# Altium schematic sheet [03] - 28PINS SCHEMATIC.SchDoc("[03] - 28PINS SCHEMATIC") (continued)

## Library data of the parts
C1 C2 = EEE-1AA101WR: CAP ALUM 100UF 10V 20% SMD; Manufacturer: Panasonic Electronic Components; .Checked PCB: RF; .Creator: MM; Capacitance: 100µF; Lifetime @ Temp.: 1000 Hrs @ 85°C; Ripple Current: 50mA; Surface Mount Land Size: 0.209" L x 0.209" W (5.30mm x 5.30mm); Applications: Automotive; Category: Capacitors; Component Kind: Standard; ComponentLink1Description: http://industrial.panasonic.com/www-cgi/jvcr13pz.cgi?E+PZ+3+ABA0002+EEE1AA101WR+7+WW; ComponentLink1URL: http://industrial.panasonic.com/www-cgi/jvcr13pz.cgi?E+PZ+3+ABA0002+EEE1AA101WR+7+WW; ESR (Equivalent Series Resistance): -; Height - Seated (Max): 0.213" (5.40mm); Impedance: -; Lead Spacing: -; Manufacturer Part Number: EEE-1AA101WR; Mounting Type: Surface Mount; Operating Temperature: -40°C ~ 85°C; Package / Case: Radial, Can - SMD; Packaging: Cut Tape (CT); Pricing 1: 1=0.44, 10=0.345, 25=0.2904, 50=0.249, 100=0.2076, 250=0.15568, 500=0.13284 (USD); RoHS: RoHS Compliant; Size / Dimension: 0.197" Dia (5.00mm); Stock 1: 50742; Supplier 1: Digi-Key; Supplier Part Number 1: PCE3867CT-ND; Tolerance: ±20%; Type: -; .Checked SCH: RF; Library Name: Integrated_Library_FEDEVEL_APR_2015.IntLib
  C1: Voltage Rating: 10V
C3 C12 C13 C19 C21 C22 C34 = C2012X5R1C106M: CAP CER 10UF 16V 20% X5R 0805; Manufacturer: TDK Corporation; Manufacturer Part Number: C2012X5R1C106M/1.25; .Checked PCB: used; Capacitance: 10µF; .Checked SCH: used; Applications: General Purpose; Category: Capacitors; Component Kind: Standard; ComponentLink1Description: http://www.tdk.com/pdf/general_B11.pdf; ComponentLink1URL: http://www.tdk.com/pdf/general_B11.pdf; Features: -; Height - Seated (Max): -; Lead Spacing: -; Lead Style: -; Mounting Type: Surface Mount, MLCC; Operating Temperature: -55°C ~ 85°C; Package / Case: 0805 (2012 Metric); Packaging: Cut Tape (CT); Pricing 1: 1=0.45, 10=0.357, 100=0.215, 250=0.16124, 500=0.1376, 1000=0.1075 (USD); Ratings: -; RoHS: RoHS Compliant; Size / Dimension: 0.079" L x 0.049" W (2.00mm x 1.25mm); Stock 1: 66161; Supplier 1: Digi-Key; Supplier Part Number 1: 445-4115-1-ND; Temperature Coefficient: X5R; Thickness (Max): 0.039" (1.00mm); Tolerance: ±20%; Library Name: Integrated_Library_FEDEVEL_APR_2015.IntLib
  C3 C22: Voltage - Rated: 16V
C4-C6 C8 C11 C14-C17 C20 C23 C24 C26 C28-C33 = C2012X7R1H104K085AA: CAP CER 0.1UF 50V 10% X7R 0805; Manufacturer: TDK Corporation; .Checked PCB: RF; .Creator: MM; Capacitance: 0.1µF; Applications: General Purpose; Category: Capacitors; Component Kind: Standard; ComponentLink1Description: http://product.tdk.com/en/catalog/datasheets/mlcc_commercial_general_en.pdf; ComponentLink1URL: http://product.tdk.com/en/catalog/datasheets/mlcc_commercial_general_en.pdf; Features: -; Height - Seated (Max): -; Lead Spacing: -; Lead Style: -; Manufacturer Part Number: C2012X7R1H104K085AA; Mounting Type: Surface Mount, MLCC; Operating Temperature: -55°C ~ 125°C; Package / Case: 0805 (2012 Metric); Packaging: Cut Tape (CT); Pricing 1: 1=0.1, 10=0.066, 100=0.0303, 250=0.02476, 500=0.02118, 1000=0.0165 (USD); Ratings: -; RoHS: RoHS Compliant; Size / Dimension: 0.079" L x 0.049" W (2.00mm x 1.25mm); Stock 1: 818066; Supplier 1: Digi-Key; Supplier Part Number 1: 445-7534-1-ND; Temperature Coefficient: X7R; Thickness (Max): 0.037" (0.95mm); Tolerance: ±10%; Voltage - Rated: 50V; .Checked SCH: RF; Library Name: Integrated_Library_FEDEVEL_APR_2015.IntLib
C7 = C2012X5R1E105K125AA: CAP CER 1UF 25V 10% X5R 0805; Manufacturer: TDK Corporation; .Checked PCB: RF; .Creator: MM; Capacitance: 1µF; Applications: General Purpose; Category: Capacitors; Component Kind: Standard; ComponentLink1Description: http://product.tdk.com/en/catalog/datasheets/mlcc_commercial_general_en.pdf; ComponentLink1URL: http://product.tdk.com/en/catalog/datasheets/mlcc_commercial_general_en.pdf; Features: -; Height - Seated (Max): -; Lead Spacing: -; Lead Style: -; Manufacturer Part Number: C2012X5R1E105K125AA; Mounting Type: Surface Mount, MLCC; Operating Temperature: -55°C ~ 85°C; Package / Case: 0805 (2012 Metric); Packaging: Cut Tape (CT); Pricing 1: 1=0.16, 10=0.112, 100=0.0533, 250=0.04488, 500=0.03758, 1000=0.03025 (USD); Ratings: -; RoHS: RoHS Compliant; Size / Dimension: 0.079" L x 0.049" W (2.00mm x 1.25mm); Stock 1: 57052; Supplier 1: Digi-Key; Supplier Part Number 1: 445-1419-1-ND; Temperature Coefficient: X5R; Thickness (Max): 0.057" (1.45mm); Tolerance: ±10%; Voltage - Rated: 25V; .Checked SCH: RF; Library Name: Integrated_Library_FEDEVEL_APR_2015.IntLib
C9 C10 C25 C27 = C0805C180J5GACTU: CAP CER 18PF 50V 5% NP0 0805; Manufacturer: Kemet; .Checked PCB: RF; .Creator: MM; Temperature Coefficient: C0G, NP0; Applications: General Purpose; Capacitance: 18pF; Category: Capacitors; Component Kind: Standard; ComponentLink1Description: http://www.kemet.com/docfinder?Partnumber=C0805C180J5GACTU; ComponentLink1URL: http://www.kemet.com/docfinder?Partnumber=C0805C180J5GACTU; Features: -; Height - Seated (Max): -; Lead Spacing: -; Lead Style: -; Manufacturer Part Number: C0805C180J5GACTU; Mounting Type: Surface Mount, MLCC; Operating Temperature: -55°C ~ 125°C; Package / Case: 0805 (2012 Metric); Packaging: Cut Tape (CT); Pricing 1: 1=0.11, 10=0.083, 25=0.0588, 50=0.045, 100=0.0381, 250=0.0312, 1000=0.0204 (USD); Ratings: -; RoHS: RoHS Compliant; Size / Dimension: 0.079" L x 0.049" W (2.00mm x 1.25mm); Stock 1: 39241; Supplier 1: Digi-Key; Supplier Part Number 1: 399-1112-1-ND; Thickness (Max): 0.035" (0.88mm); Tolerance: ±5%; Voltage - Rated: 50V; .Checked SCH: RF; Library Name: Integrated_Library_FEDEVEL_APR_2015.IntLib
D1 = LG L29K-G2J1-24-Z: LED SMARTLED GREEN 570NM 0603; Manufacturer: OSRAM Opto Semiconductors Inc; .Checked PCB: used; Current - Limit (Min): 600mA; Current - Output: 500mA; Current - Test: 2mA; Lens Style/Size: Rectangle with Flat Top, 1.3mm x 0.8mm; Lens Type: Diffused; Luminous Flux @ Current - Test: 12 mlm; Millicandela Rating: 3.92mcd; Number of Regulators: 1; Regulator Topology: Positive Fixed; Viewing Angle: 160°; Voltage - Dropout (Typical): 0.16V @ 500mA; Voltage - Forward (Vf) Typ: 1.7V; Voltage - Input: 2.6 V ~ 6 V; Voltage - Output: 2.5V; Wavelength - Peak: 572nm; .Checked SCH: used; Category: Optoelectronics; Color: Green; Component Kind: Standard; ComponentLink1Description: http://catalog.osram-os.com/catalogue/catalogue.do;jsessionid=32CB61882C089EDD4B6D0A54E7E1F117?act=downloadFile&favOid=020000020000138e000100b6; ComponentLink1URL: http://catalog.osram-os.com/catalogue/catalogue.do;jsessionid=32CB61882C089EDD4B6D0A54E7E1F117?act=downloadFile&favOid=020000020000138e000100b6; ComponentLink2Description: http://www.micrel.com/_PDF/mic5353.pdf; ComponentLink2URL: http://www.micrel.com/_PDF/mic5353.pdf; Family: LEDs - <75mA, Discre; Height: 0.65mm; Manufacturer Part Number: LG L29K-G2J1-24-Z; Mounting Type: Surface Mount; Operating Temperature: -40°C ~ 125°C; Package / Case: 0603 (1608 Metric); Packaging: Cut Tape (CT); Price 1k [$]: 0.08744; Pricing 1: 1=0.16, 10=0.137, 100=0.1093, 250=0.09564, 500=0.08744 (USD); RoHS: RoHS Compliant; Size / Dimension: 1.70mm L x 0.80mm W; Stock 1: 30318; Supplier: Digikey; Supplier 1: Digi-Key; Supplier Device Package: 6-TMLF® (1.6x1.6); Supplier Part Number: 475-2709-1-ND; Supplier Part Number 1: 475-2709-1-ND; Value: Green; Wavelength - Dominant: 570nm; Library Name: Integrated_Library_FEDEVEL_APR_2015.IntLib
D2-D4 = LO L29K-H2K1-24-Z: LED SMARTLED ORANGE 606NM 0603; Manufacturer: OSRAM Opto Semiconductors Inc; .Checked PCB: used; Current - Test: 2mA; Lens Style/Size: Rectangle with Flat Top, 1.3mm x 0.8mm; Lens Type: Diffused; Luminous Flux @ Current - Test: 25 mlm; Millicandela Rating: 6.3mcd; Viewing Angle: 160°; Voltage - Forward (Vf) Typ: 1.8V; Wavelength - Peak: 610nm; .Checked SCH: used; Category: Optoelectronics; Color: Orange; Component Kind: Standard; ComponentLink1Description: http://catalog.osram-os.com/catalogue/catalogue.do;jsessionid=302CF83AF637AE24E40AEB0ADA267EDD?act=downloadFile&favOid=020000010003f9de000100b6; ComponentLink1URL: http://catalog.osram-os.com/catalogue/catalogue.do;jsessionid=302CF83AF637AE24E40AEB0ADA267EDD?act=downloadFile&favOid=020000010003f9de000100b6; Height: 0.65mm; Manufacturer Part Number: LO L29K-H2K1-24-Z; Mounting Type: Surface Mount; Package / Case: 0603 (1608 Metric); Packaging: Cut Tape (CT); Pricing 1: 1=0.17, 10=0.141, 100=0.1127, 250=0.0986, 500=0.09016 (USD); RoHS: RoHS Compliant; Size / Dimension: 1.70mm L x 0.80mm W; Stock 1: 15900; Supplier 1: Digi-Key; Supplier Part Number 1: 475-2740-1-ND; Wavelength - Dominant: 606nm; Library Name: Integrated_Library_FEDEVEL_APR_2015.IntLib
D5 D6 = BAT54HT1G: DIODE SCHOTTKY 30V 0.2A SOD323; Manufacturer: Fairchild Semiconductor; .Checked PCB: used; .Creator: MM; Capacitance @ Vr, F: 10pF @ 1V, 1MHz; Current - Average Rectified (Io): 200mA; Current - Reverse Leakage @ Vr: 2µA @ 25V; Reverse Recovery Time (trr): 5ns; Speed: Small Signal =< 200mA (Io), Any Speed; Thermal Resistance: 600°C/W Ja; Voltage - Forward (Vf) (Max) @ If: 800mV @ 100mA; .Checked SCH: used; Category: Discrete Semiconductor Products; Component Kind: Standard; ComponentLink1Description: http://www.fairchildsemi.com/ds/BA/BAT54HT1G.pdf; ComponentLink1URL: http://www.fairchildsemi.com/ds/BA/BAT54HT1G.pdf; Diode Type: Schottky; Manufacturer Part Number: BAT54HT1G; Mounting Type: Surface Mount; Operating Temperature - Junction: -55°C ~ 150°C; Package / Case: SC-76, SOD-323; Packaging: Cut Tape (CT); Pricing 1: 1=0.3, 10=0.276, 25=0.1984, 100=0.1543, 250=0.09696, 500=0.0826, 1000=0.05628 (USD); RoHS: RoHS Compliant; Stock 1: 11388; Supplier 1: Digi-Key; Supplier Device Package: SOD-323; Supplier Part Number 1: BAT54HT1GCT-ND; Voltage - DC Reverse (Vr) (Max): 30V; Library Name: Integrated_Library_FEDEVEL_APR_2015.IntLib
FB1-FB3 = MPZ2012S601AT000: FERRITE CHIP BEAD 600 OHM SMD; Manufacturer: TDK Corporation; .Checked PCB: RF; .Creator: MM; Current Rating: 2A; DC Resistance (DCR): 100 mOhm Max; Filter Type: Differential Mode - Single; Impedance @ Frequency: 600 Ohm @ 100MHz; Category: Filters; Component Kind: Standard; ComponentLink1Description: http://product.tdk.com/en/catalog/datasheets/beads_commercial_power_mpz_en.pdf; ComponentLink1URL: http://product.tdk.com/en/catalog/datasheets/beads_commercial_power_mpz_en.pdf; Height (Max): 0.041" (1.05mm); Manufacturer Part Number: MPZ2012S601AT000; Mounting Type: Surface Mount; Package / Case: 0805 (2012 Metric); Packaging: Cut Tape (CT); Pricing 1: 1=0.12, 10=0.101, 100=0.0574, 250=0.04528, 500=0.03824, 1000=0.03221 (USD); RoHS: RoHS Compliant; Size / Dimension: 0.079" L x 0.049" W (2.00mm x 1.25mm); Stock 1: 200620; Supplier 1: Digi-Key; Supplier Part Number 1: 445-2206-1-ND; .Checked SCH: RF; Library Name: Integrated_Library_FEDEVEL_APR_2015.IntLib
FID1-FID4 = FID_1MMC: Fiducial 40mil Diameter, 120mil Mask; Supplier: Digikey; Library Name: Integrated_Library_FEDEVEL_APR_2015.IntLib
J1 J3 = M20-7820842: 08-WAY SIL VERT SOCKET L/FREE; Manufacturer: Harwin Inc; .Checked PCB: RF; .Creator: MM; Connector Type: Receptacle; Number of Positions: 8; Number of Positions Loaded: All; Pitch: 0.100" (2.54mm); Category: Connectors, Interconnects; Color: Black; Component Kind: Standard; ComponentLink1Description: http://harwin.com/includes/pdfs/M20-782.pdf; ComponentLink1URL: http://harwin.com/includes/pdfs/M20-782.pdf; Contact Finish: Gold; Contact Finish Thickness: Flash; Contact Type: Female Socket; Fastening Type: -; Features: -; Manufacturer Part Number: M20-7820842; Mounting Type: Through Hole; Number of Rows: 1; Packaging: Tube; Pricing 1: 1=1.1, 29=0.91207, 58=0.87397, 116=0.83603, 261=0.76, 522=0.684, 1015=0.608, 2523=0.551 (USD); RoHS: RoHS Compliant; Row Spacing: -; Stock 1: 2070; Supplier 1: Digi-Key; Supplier Part Number 1: 952-1823-ND; Termination: Solder; .Checked SCH: RF; Library Name: Integrated_Library_FEDEVEL_APR_2015.IntLib
J2 = M20-7820646: 06-WAY SIL VERT SOCKET L/FREE; Manufacturer: Harwin Inc; .Checked PCB: MM; Connector Type: Receptacle; Number of Positions: 6; Number of Positions Loaded: All; Pitch: 0.100" (2.54mm); Category: Connectors, Interconnects; Color: Black; Component Kind: Standard; ComponentLink1Description: http://harwin.com/includes/pdfs/M20-782.pdf; ComponentLink1URL: http://harwin.com/includes/pdfs/M20-782.pdf; Contact Finish: Tin; Contact Finish Thickness: -; Contact Type: Female Socket; Fastening Type: -; Features: -; Manufacturer Part Number: M20-7820646; Mounting Type: Through Hole; Number of Rows: 1; Packaging: Tube; Pricing 1: 1=0.96, 38=0.79211, 76=0.75895, 114=0.72596, 266=0.66, 532=0.594, 1026=0.528, 2508=0.4785 (USD); RoHS: RoHS Compliant; Row Spacing: -; Stock 1: 87; Supplier 1: Digi-Key; Supplier Part Number 1: 952-1809-ND; Termination: Solder; .Checked SCH: MM; .Creator: MM; Library Name: Integrated_Library_FEDEVEL_APR_2015.IntLib
J4 = M20-7821046: 10-WAY SIL VERT SOCKET L/FREE; Manufacturer: Harwin Inc; .Checked PCB: RF; .Creator: MM; Connector Type: Receptacle; Number of Positions: 10; Number of Positions Loaded: All; Pitch: 0.100" (2.54mm); Category: Connectors, Interconnects; Color: Black; Component Kind: Standard; ComponentLink1Description: http://harwin.com/includes/pdfs/M20-782.pdf; ComponentLink1URL: http://harwin.com/includes/pdfs/M20-782.pdf; Contact Finish: Tin; Contact Finish Thickness: -; Contact Type: Female Socket; Fastening Type: -; Features: -; Manufacturer Part Number: M20-7821046; Mounting Type: Through Hole; Number of Rows: 1; Packaging: Tube; Pricing 1: 1=1.28, 23=1.12217, 46=1.05609, 69=1.01203, 115=0.968, 253=0.88, 506=0.792, 1012=0.704, 2507=0.638 (USD); RoHS: RoHS Compliant; Row Spacing: -; Stock 1: 214; Supplier 1: Digi-Key; Supplier Part Number 1: 952-1847-ND; Termination: Solder; .Checked SCH: RF; Library Name: Integrated_Library_FEDEVEL_APR_2015.IntLib
J5 J6 = 67997-106HLF: CONN HEADER 6POS .100 STR 30AU; Manufacturer: FCI; .Checked PCB: RF; .Creator: MM; Connector Type: Header, Unshrouded; Number of Positions: 6; Number of Positions Loaded: All; Pitch: 0.100" (2.54mm); Category: Connectors, Interconnects; Color: Black; Component Kind: Standard; ComponentLink1Description: http://portal.fciconnect.com/Comergent//fci/drawing/67996.pdf; ComponentLink1URL: http://portal.fciconnect.com/Comergent//fci/drawing/67996.pdf; Contact Finish: Gold or Gold, GXT™; Contact Finish Thickness: 30µin (0.76µm); Contact Mating Length: 0.230" (5.84mm); Contact Type: Male Pin; Fastening Type: -; Features: -; Manufacturer Part Number: 67997-106HLF; Mounting Type: Through Hole; Number of Rows: 2; Packaging: Bulk; Pricing 1: 1=1.64, 10=1.489, 25=1.3976, 50=1.3368, 100=1.276, 250=1.15444, 500=1.0633, 1000=0.9114, 2500=0.85064 (USD); RoHS: RoHS Compliant; Row Spacing: 0.100" (2.54mm); Stock 1: 1377; Supplier 1: Digi-Key; Supplier Part Number 1: 609-3393-ND; Termination: Solder; .Checked SCH: RF; Library Name: Integrated_Library_FEDEVEL_APR_2015.IntLib
J7 = 10118193-0001LF: CONN USB MICRO B RECPT SMT R/A; Manufacturer: FCI; .Checked PCB: RF; .Checked SCH: MM; Connector Type: USB - micro B; Gender: Receptacle; Number of Contacts: 5; Specifications: USB 2.0; Category: Connectors, Interconnects; Component Kind: Standard; ComponentLink1Description: http://portal.fciconnect.com/Comergent//fci/drawing/10118193.pdf; ComponentLink1URL: http://portal.fciconnect.com/Comergent//fci/drawing/10118193.pdf; Features: Shielded, Solder Retention; Ingress Protection: -; Manufacturer Part Number: 10118193-0001LF; Mounting Type: Surface Mount, Right Angle, Horizontal; Packaging: Cut Tape (CT); Pricing 1: 1=0.46, 10=0.433, 25=0.3712, 50=0.3156, 100=0.3032, 250=0.27224, 500=0.25988 (USD); RoHS: RoHS Compliant; Stock 1: 28642; Supplier 1: Digi-Key; Supplier Part Number 1: 609-4616-1-ND; .Creator: MM; Library Name: Integrated_Library_FEDEVEL_APR_2015.IntLib
J8 J9 = PAD_WITH_HOLE_4MMx1.5MM: Pad with a hole 4mm x 1.5mm; Library Name: Integrated_Library_FEDEVEL_APR_2015.IntLib
JP1 JP4 = 68000-103HLF: BERGSTIK II .100" SR STRAIGHT; Manufacturer: FCI; .Checked PCB: used; .Creator: MM; Connector Type: Header, Unshrouded; Number of Positions: 3; Number of Positions Loaded: All; Pitch: 0.100" (2.54mm); .Checked SCH: used; Category: Connectors, Interconnects; Color: Black; Component Kind: Standard; ComponentLink1Description: http://portal.fciconnect.com/Comergent//fci/drawing/68000.pdf; ComponentLink1URL: http://portal.fciconnect.com/Comergent//fci/drawing/68000.pdf; Contact Finish: Gold or Gold, GXT™; Contact Finish Thickness: 30µin (0.76µm); Contact Mating Length: 0.230" (5.84mm); Contact Type: Male Pin; Fastening Type: -; Features: -; Manufacturer Part Number: 68000-103HLF; Mounting Type: Through Hole; Number of Rows: 1; Packaging: Bulk; Pricing 1: 1=0.15, 10=0.145, 25=0.108, 50=0.0924 (USD); RoHS: RoHS Compliant; Row Spacing: -; Stock 1: 37214; Supplier 1: Digi-Key; Supplier Part Number 1: 609-3461-ND; Termination: Solder; Library Name: Integrated_Library_FEDEVEL_APR_2015.IntLib
JP2 = 68602-204HLF: CONN HEADER 4POS .100 STR 15AU; Manufacturer: FCI; .Checked PCB: RF; .Creator: MM; Connector Type: Header, Unshrouded; Number of Positions: 4; Number of Positions Loaded: All; Pitch: 0.100" (2.54mm); Category: Connectors, Interconnects; Color: Black; Component Kind: Standard; ComponentLink1Description: http://portal.fciconnect.com/Comergent//fci/drawing/67996.pdf; ComponentLink1URL: http://portal.fciconnect.com/Comergent//fci/drawing/67996.pdf; Contact Finish: Gold or Gold, GXT™; Contact Finish Thickness: 15µin (0.38µm); Contact Mating Length: 0.230" (5.84mm); Contact Type: Male Pin; Fastening Type: -; Features: -; Manufacturer Part Number: 68602-204HLF; Mounting Type: Through Hole; Number of Rows: 2; Packaging: Bulk; Pricing 1: 1=0.34, 10=0.326, 25=0.2792, 50=0.2374, 100=0.2281, 250=0.20484, 500=0.19552, 1000=0.16293, 2500=0.14896 (USD); RoHS: RoHS Compliant; Row Spacing: 0.100" (2.54mm); Stock 1: 2795; Supplier 1: Digi-Key; Supplier Part Number 1: 609-3369-ND; Termination: Solder; .Checked SCH: RF; Library Name: Integrated_Library_FEDEVEL_APR_2015.IntLib
JP3 = 68001-204HLF: CONN HEADER 4POS .100 STR 15AU; Manufacturer: FCI; .Checked PCB: RF; Connector Type: Header, Unshrouded; Number of Positions: 4; Number of Positions Loaded: All; Pitch: 0.100" (2.54mm); Category: Connectors, Interconnects; Color: Black; Component Kind: Standard; ComponentLink1Description: http://portal.fciconnect.com/res/en/pdffiles/doc_search/68000.pdf; ComponentLink1URL: http://portal.fciconnect.com/res/en/pdffiles/doc_search/68000.pdf; Contact Finish: Gold; Contact Finish Thickness: 15µin (0.38µm); Contact Mating Length: 0.230" (5.84mm); Contact Type: Male Pin; Fastening Type: -; Features: -; Manufacturer Part Number: 68001-204HLF; Mounting Type: Through Hole; Number of Rows: 1; Packaging: Bulk; Pricing 1: 1=0.7, 10=0.432, 100=0.2485, 250=0.21868, 500=0.1988, 1000=0.17892, 5000=0.12674 (USD); RoHS: RoHS Compliant; Row Spacing: -; Stock 1: 8754; Supplier 1: Digi-Key; Supplier Part Number 1: 609-3402-ND; Termination: Solder; .Checked SCH: RF; Library Name: Integrated_Library_FEDEVEL_APR_2015.IntLib
L1 = L1210R2R2MDWIT: FIXED IND 2.2UH 2A 80 MOHM SMD; Manufacturer: Kemet; .Checked PCB: RF; .Creator: MM; DC Resistance (DCR): 80 mOhm; Frequency - Self Resonant: 190MHz; Frequency - Test: 100kHz; Inductance: 2.2µH; Material - Core: Ferrite; Shielding: Unshielded; Tolerance: ±20%; Type: Wirewound; Category: Inductors, Coils, Chokes; Component Kind: Standard; ComponentLink1Description: http://www.kemet.com//kemet/web/homepage/kechome.nsf/vapubfile/Ferrite%20Products%20Catalog/$file/F3115.pdf; ComponentLink1URL: http://www.kemet.com//kemet/web/homepage/kechome.nsf/vapubfile/Ferrite%20Products%20Catalog/$file/F3115.pdf; Current - Saturation: -; Height - Seated (Max): 0.106" (2.70mm); Manufacturer Part Number: L1210R2R2MDWIT; Mounting Type: Surface Mount; Operating Temperature: -40°C ~ 105°C; Package / Case: 1210 (3225 Metric); Packaging: Cut Tape (CT); Pricing 1: 1=0.52, 10=0.466, 25=0.4372, 50=0.379, 100=0.3499, 250=0.33236, 500=0.30322 (USD); Q @ Freq: -; RoHS: RoHS Compliant; Size / Dimension: 0.126" L x 0.098" W (3.20mm x 2.50mm); Stock 1: 3607; Supplier 1: Digi-Key; Supplier Device Package: 1210; Supplier Part Number 1: 399-9598-1-ND; .Checked SCH: RF; Library Name: Integrated_Library_FEDEVEL_APR_2015.IntLib
LINK1-LINK3 = QPC02SXGN-RC: CONN JUMPER SHORTING .100" GOLD; Manufacturer: Sullins Connector Solutions; .Checked SCH: used; Gender: Female Sockets; Number of Positions or Pins (Grid): 2 (1 x 2); Pitch: 0.100" (2.54mm); Category: Connectors, Interconnects; Color: -; ComponentLink1Description: http://www.sullinscorp.com/drawings/284_SXGN_11510.pdf; ComponentLink1URL: http://www.sullinscorp.com/drawings/284_SXGN_11510.pdf; Contact Finish: Gold; Contact Finish Thickness: Flash; Height: 0.235" (5.97mm); Manufacturer Part Number: QPC02SXGN-RC; Packaging: Bulk; Pricing 1: 1=0.11, 10=0.066, 25=0.0468, 50=0.0384, 100=0.033, 250=0.02792, 500=0.0258, 1000=0.0228, 2500=0.018 (USD); RoHS: RoHS Compliant; Stock 1: 23274; Supplier 1: Digi-Key; Supplier Part Number 1: S9337-ND; Type: Open Top; Library Name: Integrated_Library_FEDEVEL_APR_2015.IntLib
MH1-MH3 = MH_7.4MMC_3.2MMD: Mounting Hole 7.4mm Diameter, 3.2mm Drill; .Creator: MM; Library Name: Integrated_Library_FEDEVEL_APR_2015.IntLib
MH4 = MH_7.4MMNC_3.2MMD: Mounting Hole 7.4mm Diameter (Non Copper), 3.2mm Drill NPTH; Library Name: Integrated_Library_FEDEVEL_APR_2015.IntLib
R1 = RC0805FR-07100KL: RES SMD 100K OHM 1% 1/8W 0805; Manufacturer: Yageo; .Checked PCB: RF; .Creator: MM; Power (Watts): 0.125W, 1/8W; Temperature Coefficient: ±100ppm/°C; Category: Resistors; Component Kind: Standard; ComponentLink1Description: http://www.yageo.com/documents/recent/PYu-RC_Group_51_RoHS_L_1.pdf; ComponentLink1URL: http://www.yageo.com/documents/recent/PYu-RC_Group_51_RoHS_L_1.pdf; Composition: Thick Film; Features: Moisture Resistant; Height: 0.024" (0.60mm); Manufacturer Part Number: RC0805FR-07100KL; Number of Terminations: 2; Operating Temperature: -55°C ~ 155°C; Package / Case: 0805 (2012 Metric); Packaging: Cut Tape (CT); Pricing 1: 1=0.1, 10=0.021, 25=0.0148, 100=0.0084, 250=0.0064, 500=0.0051, 1000=0.00376, 2500=0.00326 (USD); Resistance (Ohms): 100k; RoHS: RoHS Compliant; Size / Dimension: 0.079" L x 0.049" W (2.00mm x 1.25mm); Stock 1: 1604881; Supplier 1: Digi-Key; Supplier Device Package: 0805; Supplier Part Number 1: 311-100KCRCT-ND; Tolerance: ±1%; .Checked SCH: RF; Library Name: Integrated_Library_FEDEVEL_APR_2015.IntLib
R2 R3 R15 R17 R22 = RC0805FR-07560RL: RES SMD 560 OHM 1% 1/8W 0805; Manufacturer: Yageo; .Checked PCB: RF; .Creator: MM; Power (Watts): 0.125W, 1/8W; Resistance (Ohms): 560; Temperature Coefficient: ±100ppm/°C; Category: Resistors; Component Kind: Standard; ComponentLink1Description: http://www.yageo.com/documents/recent/PYu-RC_Group_51_RoHS_L_1.pdf; ComponentLink1URL: http://www.yageo.com/documents/recent/PYu-RC_Group_51_RoHS_L_1.pdf; Composition: Thick Film; Features: Moisture Resistant; Height: 0.024" (0.60mm); Manufacturer Part Number: RC0805FR-07560RL; Number of Terminations: 2; Operating Temperature: -55°C ~ 155°C; Package / Case: 0805 (2012 Metric); Packaging: Cut Tape (CT); Pricing 1: 1=0.1, 10=0.021, 25=0.0148, 100=0.0084, 250=0.0064, 500=0.0051, 1000=0.00376, 2500=0.00326 (USD); RoHS: RoHS Compliant; Size / Dimension: 0.079" L x 0.049" W (2.00mm x 1.25mm); Stock 1: 53313; Supplier 1: Digi-Key; Supplier Device Package: 0805; Supplier Part Number 1: 311-560CRCT-ND; Tolerance: ±1%; .Checked SCH: RF; Library Name: Integrated_Library_FEDEVEL_APR_2015.IntLib
R4 R14 R18-R21 = RC0805FR-0710KL: RES SMD 10K OHM 1% 1/8W 0805; Manufacturer: Yageo; .Checked PCB: RF; .Creator: MM; Power (Watts): 0.125W, 1/8W; Temperature Coefficient: ±100ppm/°C; Category: Resistors; Component Kind: Standard; ComponentLink1Description: http://www.yageo.com/documents/recent/PYu-RC_Group_51_RoHS_L_1.pdf; ComponentLink1URL: http://www.yageo.com/documents/recent/PYu-RC_Group_51_RoHS_L_1.pdf; Composition: Thick Film; Features: Moisture Resistant; Height: 0.024" (0.60mm); Manufacturer Part Number: RC0805FR-0710KL; Number of Terminations: 2; Operating Temperature: -55°C ~ 155°C; Package / Case: 0805 (2012 Metric); Packaging: Cut Tape (CT); Pricing 1: 1=0.1, 10=0.021, 25=0.0148, 100=0.0084, 250=0.0064, 500=0.0051, 1000=0.00376, 2500=0.00326 (USD); Resistance (Ohms): 10k; RoHS: RoHS Compliant; Size / Dimension: 0.079" L x 0.049" W (2.00mm x 1.25mm); Stock 1: 4094384; Supplier 1: Digi-Key; Supplier Device Package: 0805; Supplier Part Number 1: 311-10.0KCRCT-ND; Tolerance: ±1%; .Checked SCH: RF; Library Name: Integrated_Library_FEDEVEL_APR_2015.IntLib
R5-R7 R13 R23-R25 = RC0805FR-0722RL: RES SMD 22 OHM 1% 1/8W 0805; Manufacturer: Yageo; .Checked PCB: RF; .Creator: MM; Power (Watts): 0.125W, 1/8W; Resistance (Ohms): 22; Temperature Coefficient: ±100ppm/°C; Category: Resistors; Component Kind: Standard; ComponentLink1Description: http://www.yageo.com/documents/recent/PYu-RC_Group_51_RoHS_L_1.pdf; ComponentLink1URL: http://www.yageo.com/documents/recent/PYu-RC_Group_51_RoHS_L_1.pdf; Composition: Thick Film; Features: Moisture Resistant; Height: 0.024" (0.60mm); Manufacturer Part Number: RC0805FR-0722RL; Number of Terminations: 2; Operating Temperature: -55°C ~ 155°C; Package / Case: 0805 (2012 Metric); Packaging: Cut Tape (CT); Pricing 1: 1=0.1, 10=0.021, 25=0.0148, 100=0.0084, 250=0.0064, 500=0.0051, 1000=0.00376, 2500=0.00326 (USD); RoHS: RoHS Compliant; Size / Dimension: 0.079" L x 0.049" W (2.00mm x 1.25mm); Stock 1: 63849; Supplier 1: Digi-Key; Supplier Device Package: 0805; Supplier Part Number 1: 311-22.0CRCT-ND; Tolerance: ±1%; .Checked SCH: RF; Library Name: Integrated_Library_FEDEVEL_APR_2015.IntLib
R8 R26-R28 = RC0805JR-070RL: RES 0.0 OHM 1/8W 0805 SMD; Manufacturer: Yageo; .Checked PCB: RF; Power (Watts): 0.125W, 1/8W; Resistance (Ohms): 0.0; Tolerance: Jumper; Category: Resistors; Component Kind: Standard; ComponentLink1Description: http://www.yageo.com/documents/recent/PYu-RC0805_51_RoHS_L_4.pdf; ComponentLink1URL: http://www.yageo.com/documents/recent/PYu-RC0805_51_RoHS_L_4.pdf; Composition: Thick Film; Features: -; Height: 0.024" (0.60mm); Manufacturer Part Number: RC0805JR-070RL; Number of Terminations: 2; Package / Case: 0805 (2012 Metric); Packaging: Cut Tape (CT); Price 1k [$]: 0.01479; Pricing 1: 10=0.017, 50=0.0126, 100=0.0096, 250=0.00716, 500=0.00528, 1000=0.00352 (USD); RoHS: RoHS Compliant; Size / Dimension: 0.079" L x 0.049" W (2.00mm x 1.25mm); Stock 1: 933202; Supplier: Digikey; Supplier 1: Digi-Key; Supplier Part Number: 311-0.0ARCT-ND; Supplier Part Number 1: 311-0.0ARCT-ND; Temperature Coefficient: -; Value: 0R; .Checked SCH: RF; Library Name: Integrated_Library_FEDEVEL_APR_2015.IntLib
R9 R16 = RC0805FR-071ML: RES SMD 1M OHM 1% 1/8W 0805; Manufacturer: Yageo; .Checked PCB: RF; .Creator: MM; Power (Watts): 0.125W, 1/8W; Temperature Coefficient: ±100ppm/°C; Category: Resistors; Component Kind: Standard; ComponentLink1Description: http://www.yageo.com/documents/recent/PYu-RC_Group_51_RoHS_L_1.pdf; ComponentLink1URL: http://www.yageo.com/documents/recent/PYu-RC_Group_51_RoHS_L_1.pdf; Composition: Thick Film; Features: Moisture Resistant; Height: 0.024" (0.60mm); Manufacturer Part Number: RC0805FR-071ML; Number of Terminations: 2; Operating Temperature: -55°C ~ 155°C; Package / Case: 0805 (2012 Metric); Packaging: Cut Tape (CT); Pricing 1: 1=0.1, 10=0.021, 25=0.0148, 100=0.0084, 250=0.0064, 500=0.0051, 1000=0.00376, 2500=0.00326 (USD); Resistance (Ohms): 1M; RoHS: RoHS Compliant; Size / Dimension: 0.079" L x 0.049" W (2.00mm x 1.25mm); Stock 1: 449644; Supplier 1: Digi-Key; Supplier Device Package: 0805; Supplier Part Number 1: 311-1.00MCRCT-ND; Tolerance: ±1%; .Checked SCH: RF; Library Name: Integrated_Library_FEDEVEL_APR_2015.IntLib
R10-R12 = RC0805FR-071KL: RES SMD 1K OHM 1% 1/8W 0805; Manufacturer: Yageo; .Checked PCB: RF; .Creator: MM; Power (Watts): 0.125W, 1/8W; Temperature Coefficient: ±100ppm/°C; Category: Resistors; Component Kind: Standard; ComponentLink1Description: http://www.yageo.com/documents/recent/PYu-RC_Group_51_RoHS_L_1.pdf; ComponentLink1URL: http://www.yageo.com/documents/recent/PYu-RC_Group_51_RoHS_L_1.pdf; Composition: Thick Film; Features: Moisture Resistant; Height: 0.024" (0.60mm); Manufacturer Part Number: RC0805FR-071KL; Number of Terminations: 2; Operating Temperature: -55°C ~ 155°C; Package / Case: 0805 (2012 Metric); Packaging: Cut Tape (CT); Pricing 1: 1=0.1, 10=0.021, 25=0.0148, 100=0.0084, 250=0.0064, 500=0.0051, 1000=0.00376, 2500=0.00326 (USD); Resistance (Ohms): 1k; RoHS: RoHS Compliant; Size / Dimension: 0.079" L x 0.049" W (2.00mm x 1.25mm); Stock 1: 3497185; Supplier 1: Digi-Key; Supplier Device Package: 0805; Supplier Part Number 1: 311-1.00KCRCT-ND; Tolerance: ±1%; .Checked SCH: RF; Library Name: Integrated_Library_FEDEVEL_APR_2015.IntLib
SCKT_FOR_U1 = 4828-3004-CP: CONN IC DIP SOCKET 28POS TIN; Manufacturer: 3M; .Checked PCB: RF; .Creator: MM; Current Rating: 1A; Material Flammability Rating: UL94 V-0; Number of Positions or Pins (Grid): 28 (2 x 14); Pitch - Mating: 0.100" (2.54mm); Category: Connectors, Interconnects; Component Kind: Standard; ComponentLink1Description: http://multimedia.3m.com/mws/mediawebserver?66666UuZjcFSLXTt4X&Em8&aEVuQEcuZgVs6EVs6E666666--; ComponentLink1URL: http://multimedia.3m.com/mws/mediawebserver?66666UuZjcFSLXTt4X&Em8&aEVuQEcuZgVs6EVs6E666666--; Contact Finish - Mating: Tin; Contact Finish - Post: Tin; Contact Finish Thickness - Mating: 35µin (0.90µm); Contact Finish Thickness - Post: 35µin (0.90µm); Contact Material - Mating: Phosphor Bronze; Contact Material - Post: Phosphor Bronze; Contact Resistance: -; Features: Open Frame; Housing Material: Polyester, Glass Filled; Manufacturer Part Number: 4828-3004-CP; Mounting Type: Through Hole; Operating Temperature: -25°C ~ 85°C; Packaging: Tube; Pitch - Post: 0.100" (2.54mm); Pricing 1: 1=0.77, 10=0.72, 25=0.648, 50=0.576, 100=0.552, 250=0.504, 500=0.48, 1000=0.396, 2500=0.36 (USD); RoHS: RoHS Compliant; Stock 1: 6559; Supplier 1: Digi-Key; Supplier Part Number 1: 3M5480-ND; Termination: Solder; Termination Post Length: -; Type: DIP, 0.3" (7.62mm) Row Spacing; .Checked SCH: RF; Library Name: Integrated_Library_FEDEVEL_APR_2015.IntLib
SW1 SW2 = 1825910-6: SWITCH TACTILE SPST-NO 0.05A 24V; Manufacturer: TE Connectivity; .Checked PCB: RF; .Creator: MM; Actuator Height off PCB, from Pins: 5.00mm; Actuator Material: Polybutylene Terephthalate (PBT); Actuator Orientation: Top Actuated; Actuator Type: Standard; Contact Rating @ Voltage: 0.05A @ 24VDC; Illumination: Non-Illuminated; Mechanical Life: 100,000 Cycles; Operating Force: 160gf; Outline: 6.00mm x 6.00mm; Switch Function: Off-Mom; Switch Travel: 0.25mm; Category: Switches; Circuit: SPST-NO; Component Kind: Standard; ComponentLink1Description: http://www.te.com/commerce/DocumentDelivery/DDEController?Action=srchrtrv&DocNm=1825910&DocType=Customer+Drawing&DocLang=English; ComponentLink1URL: http://www.te.com/commerce/DocumentDelivery/DDEController?Action=srchrtrv&DocNm=1825910&DocType=Customer+Drawing&DocLang=English; Features: -; Illumination Type, Color: -; Illumination Voltage (Nominal): -; Ingress Protection: -; Manufacturer Part Number: 1825910-6; Mounting Type: Through Hole; Operating Temperature: -35°C ~ 85°C; Packaging: Bulk; Pricing 1: 1=0.1, 10=0.095, 25=0.0912, 50=0.088, 100=0.0831, 250=0.07824, 500=0.07498, 1000=0.05705 (USD); RoHS: RoHS Compliant; Stock 1: 181823; Supplier 1: Digi-Key; Supplier Part Number 1: 450-1650-ND; Termination Style: PC Pin; .Checked SCH: RF; Library Name: Integrated_Library_FEDEVEL_APR_2015.IntLib
U1 = ATMEGA328P-PU: IC MCU 8BIT 32KB FLASH 28DIP; Manufacturer: Atmel; .Checked PCB: RF; .Creator: MM; Connectivity: I²C, SPI, UART/USART; Core Processor: AVR; Core Size: 8-Bit; Data Converters: A/D 6x10b; EEPROM Size: 1K x 8; Number of I/O: 23; Oscillator Type: Internal; Peripherals: Brown-out Detect/Reset, POR, PWM, WDT; Program Memory Size: 32KB (16K x 16); RAM Size: 2K x 8; Speed: 20MHz; Voltage - Supply (Vcc/Vdd): 1.8 V ~ 5.5 V; Category: Integrated Circuits (ICs); Component Kind: Standard; ComponentLink1Description: http://www.atmel.com/images/atmel-8271-8-bit-avr-microcontroller-atmega48a-48pa-88a-88pa-168a-168pa-328-328p_datasheet_summary.pdf; ComponentLink1URL: http://www.atmel.com/images/atmel-8271-8-bit-avr-microcontroller-atmega48a-48pa-88a-88pa-168a-168pa-328-328p_datasheet_summary.pdf; Manufacturer Part Number: ATMEGA328P-PU; Operating Temperature: -40°C ~ 85°C; Package / Case: 28-DIP (0.300", 7.62mm); Packaging: Tube; Pricing 1: 1=3.7, 10=3.305, 100=2.7103, 250=2.44588, 500=2.19468, 1000=1.85094 (USD); Program Memory Type: FLASH; RoHS: RoHS Compliant; Stock 1: 47234; Supplier 1: Digi-Key; Supplier Device Package: 28-PDIP; Supplier Part Number 1: ATMEGA328P-PU-ND; .Checked SCH: RF; Library Name: Integrated_Library_FEDEVEL_APR_2015.IntLib
U2 = ATMEGA16U2-AU: IC MCU 8BIT 16KB FLASH 32TQFP; Manufacturer: Atmel; .Checked PCB: RF; .Creator: MM; Connectivity: SPI, UART/USART, USB; Core Processor: AVR; Core Size: 8-Bit; EEPROM Size: 512 x 8; Number of I/O: 22; Oscillator Type: Internal; Peripherals: Brown-out Detect/Reset, POR, PWM, WDT; Program Memory Size: 16KB (8K x 16); Speed: 16MHz; Voltage - Supply (Vcc/Vdd): 2.7 V ~ 5.5 V; Category: Integrated Circuits (ICs); Component Kind: Standard; ComponentLink1Description: http://www.atmel.com/Images/7799s.pdf; ComponentLink1URL: http://www.atmel.com/Images/7799s.pdf; Data Converters: -; Manufacturer Part Number: ATMEGA16U2-AU; Operating Temperature: -40°C ~ 85°C; Package / Case: 32-TQFP; Packaging: Tray; Pricing 1: 1=4.35, 10=3.881, 25=3.4932, 100=3.1826, 250=2.87212, 500=2.57716, 1000=2.1735 (USD); Program Memory Type: FLASH; RAM Size: 512 x 8; RoHS: RoHS Compliant; Stock 1: 8549; Supplier 1: Digi-Key; Supplier Device Package: 32-TQFP (7x7); Supplier Part Number 1: ATMEGA16U2-AU-ND; .Checked SCH: RF; Library Name: Integrated_Library_FEDEVEL_APR_2015.IntLib
U3 = TL1963A-33DCQR: IC REG LDO 3.3V 1.5A SOT223-6; Manufacturer: Texas Instruments; .Checked PCB: RF; .Creator: MM; Current - Limit (Min): 1.6A; Number of Regulators: 1; Regulator Topology: Positive Fixed; Voltage - Dropout (Typical): 0.34V @ 1.5A; Voltage - Input: Up to 20V; Category: Integrated Circuits (ICs); Component Kind: Standard; ComponentLink1Description: http://www.ti.com/lit/ds/symlink/tl1963a.pdf; ComponentLink1URL: http://www.ti.com/lit/ds/symlink/tl1963a.pdf; Current - Output: 1.5A; Manufacturer Part Number: TL1963A-33DCQR; Mounting Type: Surface Mount; Operating Temperature: -40°C ~ 125°C; Package / Case: SOT-223-6; Packaging: Cut Tape (CT); Pricing 1: 1=3.11, 10=2.786, 25=2.5056, 100=2.2788, 250=2.0628, 500=1.8468, 750=1.674, 1000=1.35 (USD); RoHS: RoHS Compliant; Stock 1: 1690; Supplier 1: Digi-Key; Supplier Device Package: SOT-223-6; Supplier Part Number 1: 296-24534-1-ND; Voltage - Output: 3.3V; .Checked SCH: RF; Library Name: Integrated_Library_FEDEVEL_APR_2015.IntLib
U4-U7 = SN74AHC1G09DBVR: IC GATE AND SGL 2INP SOT23-5; Manufacturer: Texas Instruments; .Checked PCB: used; .Creator: MM; Current - Output High, Low: -, 8mA; Current - Quiescent (Max): 1µA; Logic Level - High: 1.5 V ~ 3.85 V; Logic Level - Low: 0.5 V ~ 1.65 V; Logic Type: AND Gate; Max Propagation Delay @ V, Max CL: 7.5ns @ 5V, 50pF; Number of Circuits: 1; Number of Inputs: 2; Voltage - Supply: 2 V ~ 5.5 V; .Checked SCH: used; Category: Integrated Circuits (ICs); Component Kind: Standard; ComponentLink1Description: http://www.ti.com/lit/ds/symlink/sn74ahc1g09.pdf; ComponentLink1URL: http://www.ti.com/lit/ds/symlink/sn74ahc1g09.pdf; Features: Open Drain; Manufacturer Part Number: SN74AHC1G09DBVR; Mounting Type: Surface Mount; Operating Temperature: -55°C ~ 125°C; Package / Case: SC-74A, SOT-753; Packaging: Cut Tape (CT); Pricing 1: 1=0.49, 10=0.345, 25=0.2836, 100=0.2265, 250=0.165, 500=0.134, 1000=0.103 (USD); RoHS: RoHS Compliant; Stock 1: 3468; Supplier 1: Digi-Key; Supplier Device Package: SOT-23-5; Supplier Part Number 1: 296-29202-1-ND; Library Name: Integrated_Library_FEDEVEL_APR_2015.IntLib
Y1 = ABM7-10.0000MHZ-D2Y-T: CRYSTAL 10MHZ 18PF SMD; Manufacturer: Abracon LLC; .Creator: MM; ESR (Equivalent Series Resistance): 60 Ohm; Frequency Stability: ±30ppm; Frequency Tolerance: ±20ppm; Operating Mode: Fundamental; Category: Crystals and Oscillators; Component Kind: Standard; ComponentLink1Description: http://www.abracon.com/Resonators/abm7.pdf; ComponentLink1URL: http://www.abracon.com/Resonators/abm7.pdf; Frequency: 10MHz; Height: 0.055" (1.40mm); Load Capacitance: 18pF; Manufacturer Part Number: ABM7-10.0000MHZ-D2Y-T; Mounting Type: Surface Mount; Operating Temperature: -40°C ~ 85°C; Package / Case: 2-SMD; Packaging: Cut Tape (CT); Pricing 1: 1=0.96, 10=0.847, 50=0.791, 100=0.7, 500=0.6615 (USD); Ratings: -; RoHS: RoHS Compliant; Size / Dimension: 0.236" L x 0.138" W (6.00mm x 3.50mm); Stock 1: 9355; Supplier 1: Digi-Key; Supplier Part Number 1: 535-9833-1-ND; Type: MHz Crystal; Library Name: Integrated_Library_FEDEVEL_APR_2015.IntLib
Y1 Y2 = ABM7-16.000MHZ-D2Y-T: CRYSTAL 16MHZ 18PF SMD; Manufacturer: Abracon LLC; .Checked PCB: RF; .Creator: MM; ESR (Equivalent Series Resistance): 50 Ohm; Frequency Stability: ±30ppm; Frequency Tolerance: ±20ppm; Operating Mode: Fundamental; Category: Crystals and Oscillators; Component Kind: Standard; ComponentLink1Description: http://www.abracon.com/Resonators/abm7.pdf; ComponentLink1URL: http://www.abracon.com/Resonators/abm7.pdf; Frequency: 16MHz; Height: 0.055" (1.40mm); Load Capacitance: 18pF; Manufacturer Part Number: ABM7-16.000MHZ-D2Y-T; Mounting Type: Surface Mount; Operating Temperature: -40°C ~ 85°C; Package / Case: 2-SMD; Packaging: Cut Tape (CT); Pricing 1: 1=0.96, 10=0.847, 50=0.791, 100=0.7, 500=0.6615 (USD); Ratings: -; RoHS: RoHS Compliant; Size / Dimension: 0.236" L x 0.138" W (6.00mm x 3.50mm); Stock 1: 5861; Supplier 1: Digi-Key; Supplier Part Number 1: 535-9840-1-ND; Type: MHz Crystal; .Checked SCH: RF; Library Name: Integrated_Library_FEDEVEL_APR_2015.IntLib
Y2 = ABM7-8.000MHZ-D2Y-T: CRYSTAL 8MHZ 18PF SMD; Manufacturer: Abracon LLC; .Creator: MM; ESR (Equivalent Series Resistance): 100 Ohm; Frequency Stability: ±30ppm; Frequency Tolerance: ±20ppm; Operating Mode: Fundamental; Category: Crystals and Oscillators; Component Kind: Standard; ComponentLink1Description: http://www.abracon.com/Resonators/abm7.pdf; ComponentLink1URL: http://www.abracon.com/Resonators/abm7.pdf; Frequency: 8MHz; Height: 0.055" (1.40mm); Load Capacitance: 18pF; Manufacturer Part Number: ABM7-8.000MHZ-D2Y-T; Mounting Type: Surface Mount; Operating Temperature: -40°C ~ 85°C; Package / Case: 2-SMD; Packaging: Cut Tape (CT); Pricing 1: 1=0.96, 10=0.847, 50=0.791, 100=0.7, 500=0.6615 (USD); Ratings: -; RoHS: RoHS Compliant; Size / Dimension: 0.236" L x 0.138" W (6.00mm x 3.50mm); Stock 1: 2548; Supplier 1: Digi-Key; Supplier Part Number 1: 535-9831-1-ND; Type: MHz Crystal; Library Name: Integrated_Library_FEDEVEL_APR_2015.IntLib
Z1 Z2 = CG0603MLC-05E: VARISTOR 0603; Manufacturer: Bourns Inc.; .Checked PCB: RF; .Creator: MM; Maximum DC Volts: 5VDC; Number of Circuits: 1; Category: Circuit Protection; Component Kind: Standard; ComponentLink1Description: http://www.bourns.com/data/global/pdfs/MLC.pdf; ComponentLink1URL: http://www.bourns.com/data/global/pdfs/MLC.pdf; Current - Surge: -; Energy: -; Manufacturer Part Number: CG0603MLC-05E; Maximum AC Volts: -; Mounting Type: Surface Mount, MLCV; Package / Case: 0603 (1608 Metric); Packaging: Cut Tape (CT); Pricing 1: 1=0.19, 10=0.177, 25=0.164, 50=0.158, 100=0.1472, 250=0.13104, 500=0.1092, 1000=0.10348, 2500=0.09256 (USD); RoHS: RoHS Compliant; Stock 1: 104704; Supplier 1: Digi-Key; Supplier Part Number 1: CG0603MLC-05ECT-ND; Varistor Voltage (Max): -; Varistor Voltage (Min): -; Varistor Voltage (Typ): -; .Checked SCH: RF; Library Name: Integrated_Library_FEDEVEL_APR_2015.IntLib
